# Altium schematic sheet mcu.SchDoc
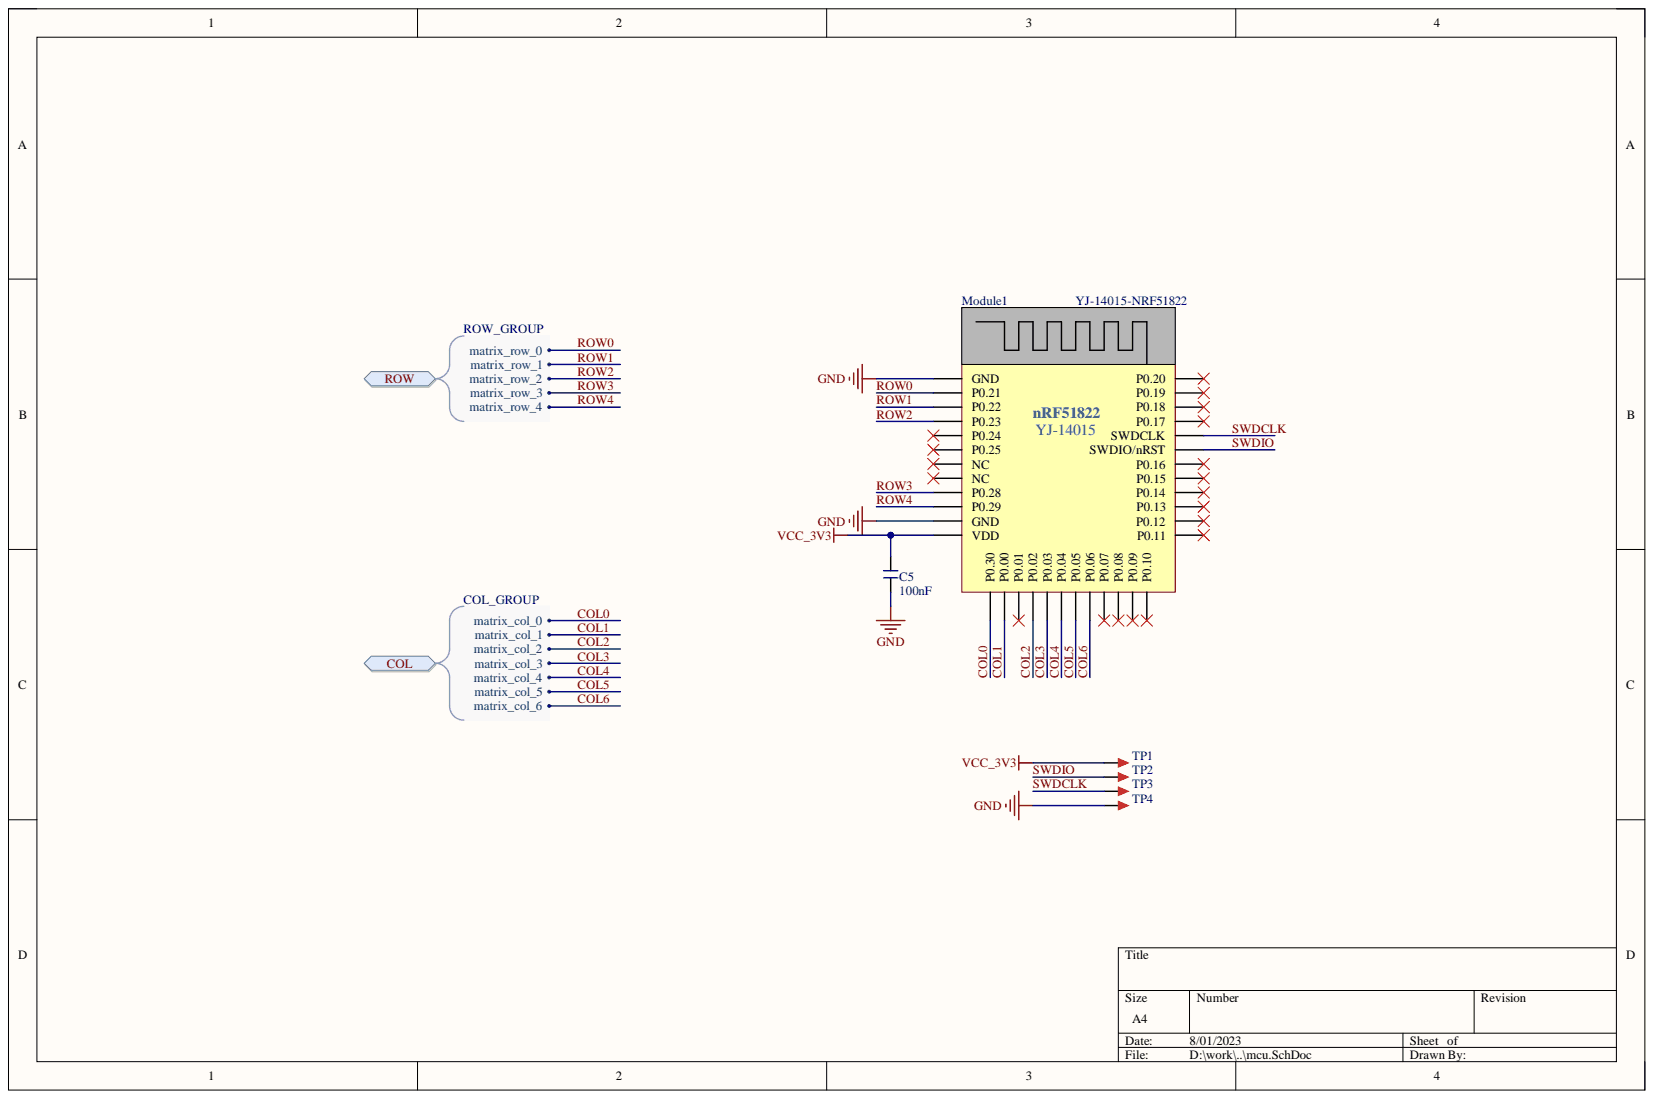
[diagram p0: mcu.SchDoc]

## Parts
C5  100nF  [C 0402]
Module1  YJ-14015-NRF51822  [YJ-14015-NRF51822]
TP1  TEST  [TEST 1.8]  (value not drawn)
TP2  TEST  [TEST 1.8]  (value not drawn)
TP3  TEST  [TEST 1.8]  (value not drawn)
TP4  TEST  [TEST 1.8]  (value not drawn)

## Ports
COL, COL.matrix_col_0, COL.matrix_col_1, COL.matrix_col_2, COL.matrix_col_3, COL.matrix_col_4, COL.matrix_col_5, COL.matrix_col_6, ROW, ROW.matrix_row_0, ROW.matrix_row_1, ROW.matrix_row_2, ROW.matrix_row_3, ROW.matrix_row_4

## Nets
GND: C5.1 Module1.1(GND) Module1.11(GND) TP4.1
VCC_3V3: C5.2 Module1.12(VDD) TP1.1
COL0: Module1.13(P0.30) ; port COL
COL1: Module1.14(P0.00) ; port COL
COL2: Module1.16(P0.02) ; port COL
COL3: Module1.17(P0.03) ; port COL
COL4: Module1.18(P0.04) ; port COL
COL5: Module1.19(P0.05) ; port COL
COL6: Module1.20(P0.06) ; port COL
ROW0: Module1.2(P0.21) ; port ROW
ROW1: Module1.3(P0.22) ; port ROW
ROW2: Module1.4(P0.23) ; port ROW
ROW3: Module1.9(P0.28) ; port ROW
ROW4: Module1.10(P0.29) ; port ROW
SWDCLK: Module1.32(SWDCLK) TP3.1
SWDIO: Module1.31(SWDIO/nRST) TP2.1

Not connected: Module1 5(P0.24) 6(P0.25) 7(NC) 8(NC) 15(P0.01) 21(P0.07) 22(P0.08) 23(P0.09) 24(P0.10) 25(P0.11) 26(P0.12) 27(P0.13) 28(P0.14) 29(P0.15) 30(P0.16) 33(P0.17) 34(P0.18) 35(P0.19) 36(P0.20)

## Library data of the parts
C5 = C: 无极性贴片电容; 版权归属: 源创客; BOM名称: 无极性贴片电容; Component Kind: Standard; Library Name: 电阻-电容-电感.SchLib; 学习资源: www.ooocke.com
TP1-TP4 = TEST: 测试点; 版权归属: 源创客; BOM名称: 测试点; Component Kind: Standard; Library Name: MyPCBLib.SchLib; 学习资源: www.ooocke.com
Module1 = YJ-14015-NRF51822: Component Kind: Standard; Library Name: Modules.SchLib
